# Altium schematic sheet Stub_Tester.SchDoc
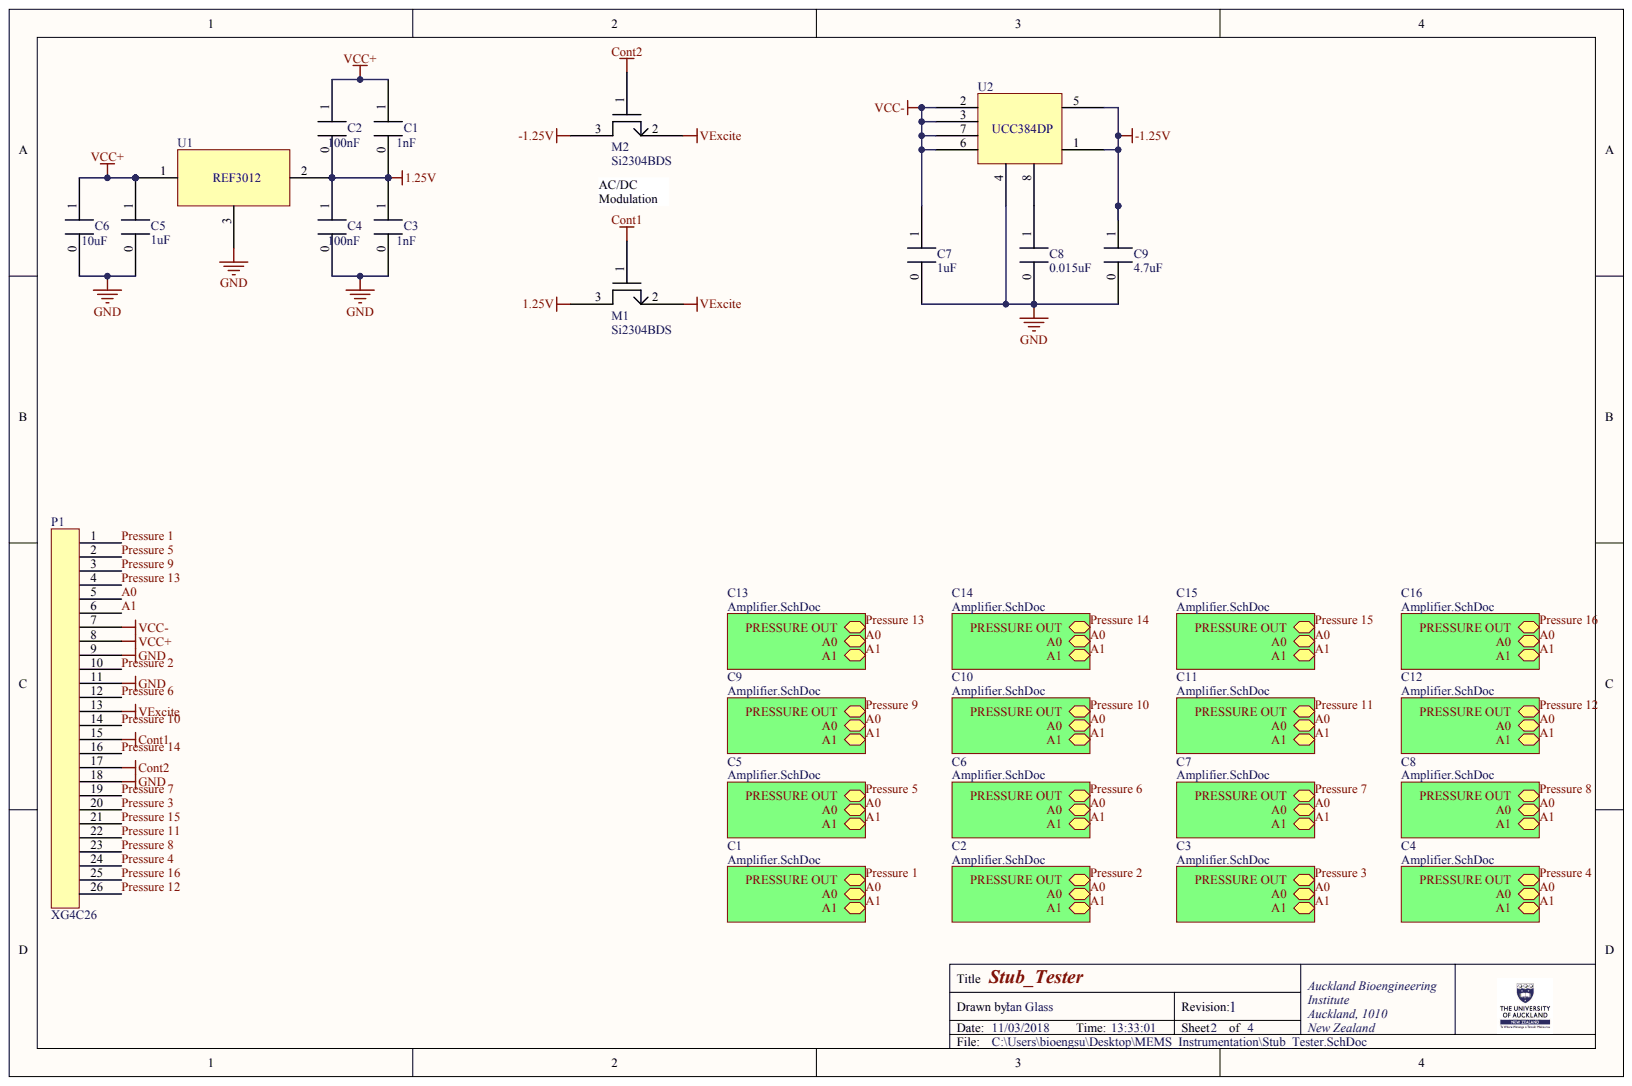
[diagram p0: Stub_Tester.SchDoc]

## Parts
C1  1nF
C2  100nF
C3  1nF
C4  100nF
C5  1uF
C6  10uF
C7  1uF
C8  0.015uF
C9  4.7uF
M1  Si2304BDS
M2  Si2304BDS
P1  XG4C26
U1  REF3012
U2  UCC384DP

## Nets
1.25V: C1.0 C2.0 C3.1 C4.1 M1.3 U1.2
-1.25V: C9.1 M2.3 U2.1 U2.5
CONT1: M1.1 P1.15
CONT2: M2.1 P1.17
GND: C3.0 C4.0 C5.0 C6.0 C7.0 C8.0 C9.0 P1.9 P1.11 P1.18 U1.3 U2.4
VCC+: C1.1 C2.1 C5.1 C6.1 P1.8 U1.1
VCC-: C7.1 P1.7 U2.2 U2.3 U2.6 U2.7
VEXCITE: M1.2 M2.2 P1.13
A0: P1.5
A1: P1.6
PRESSURE 1: P1.1
PRESSURE 2: P1.10
PRESSURE 3: P1.20
PRESSURE 4: P1.24
PRESSURE 5: P1.2
PRESSURE 6: P1.12
PRESSURE 7: P1.19
PRESSURE 8: P1.23
PRESSURE 9: P1.3
PRESSURE 10: P1.14
PRESSURE 11: P1.22
PRESSURE 12: P1.26
PRESSURE 13: P1.4
PRESSURE 14: P1.16
PRESSURE 15: P1.21
PRESSURE 16: P1.25
NetC8_1: C8.1 U2.8
